# Altium schematic sheet 1_MISC.SchDoc(1_MISC)
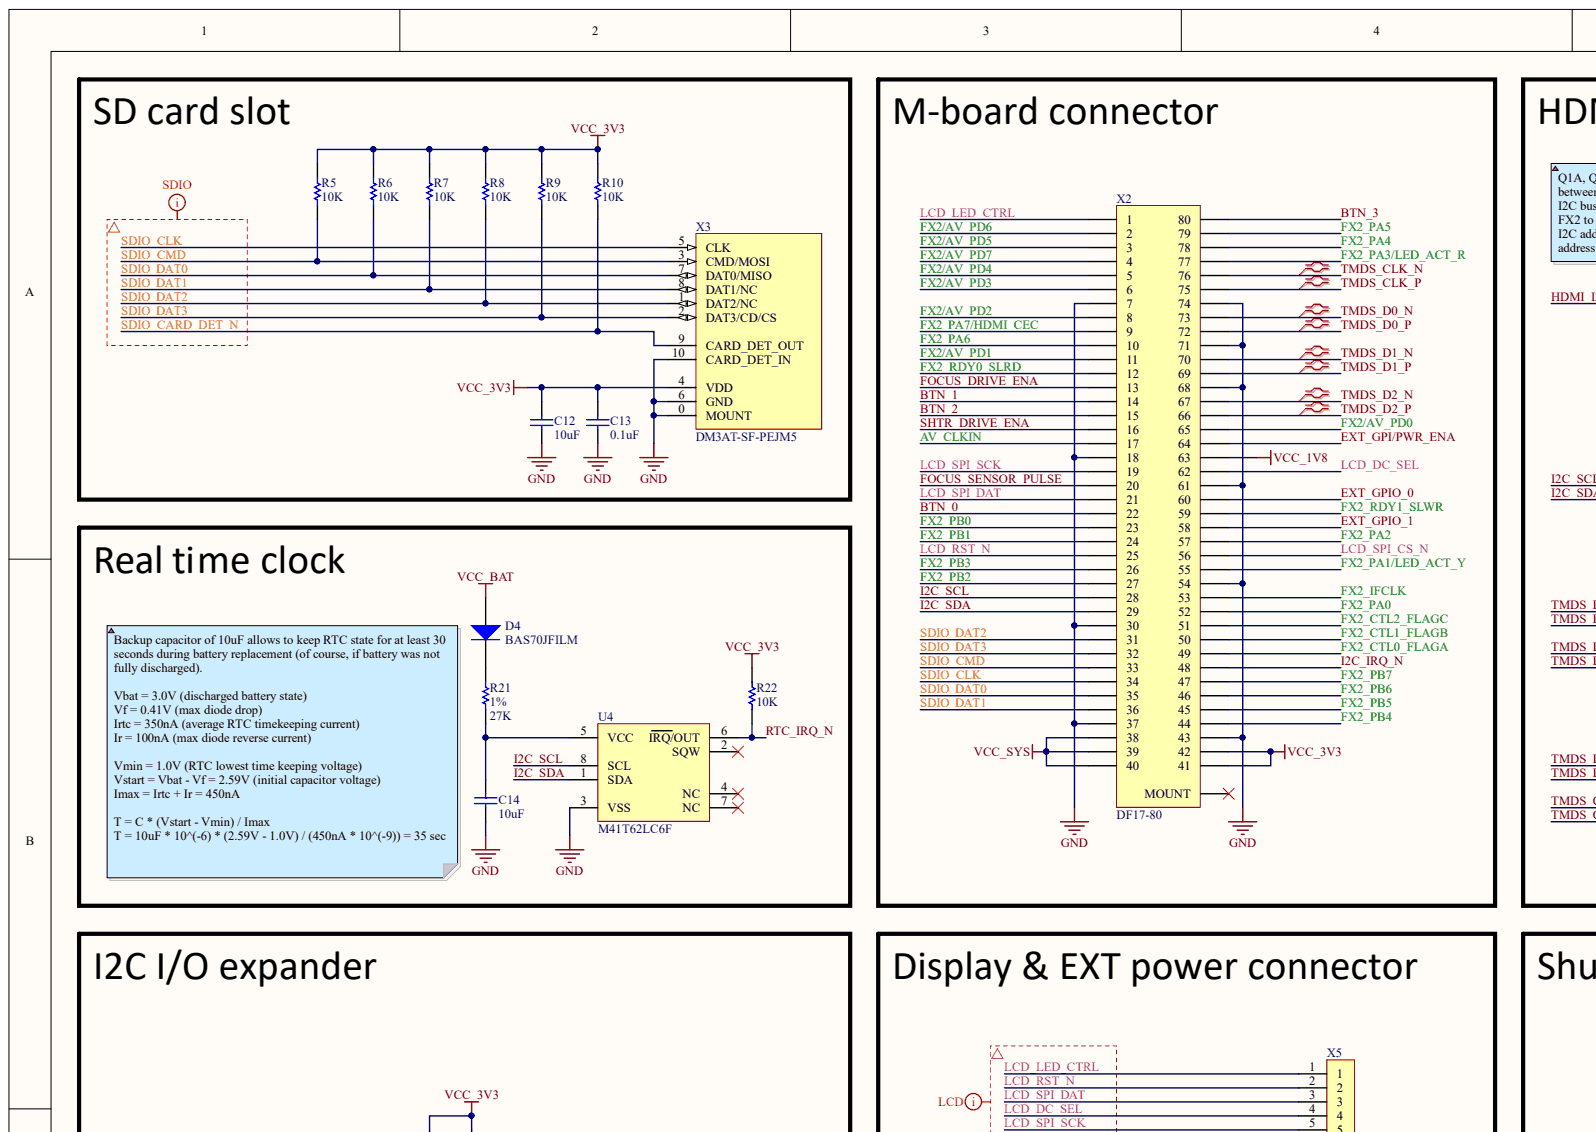
[diagram p0: 1_MISC.SchDoc, top left]
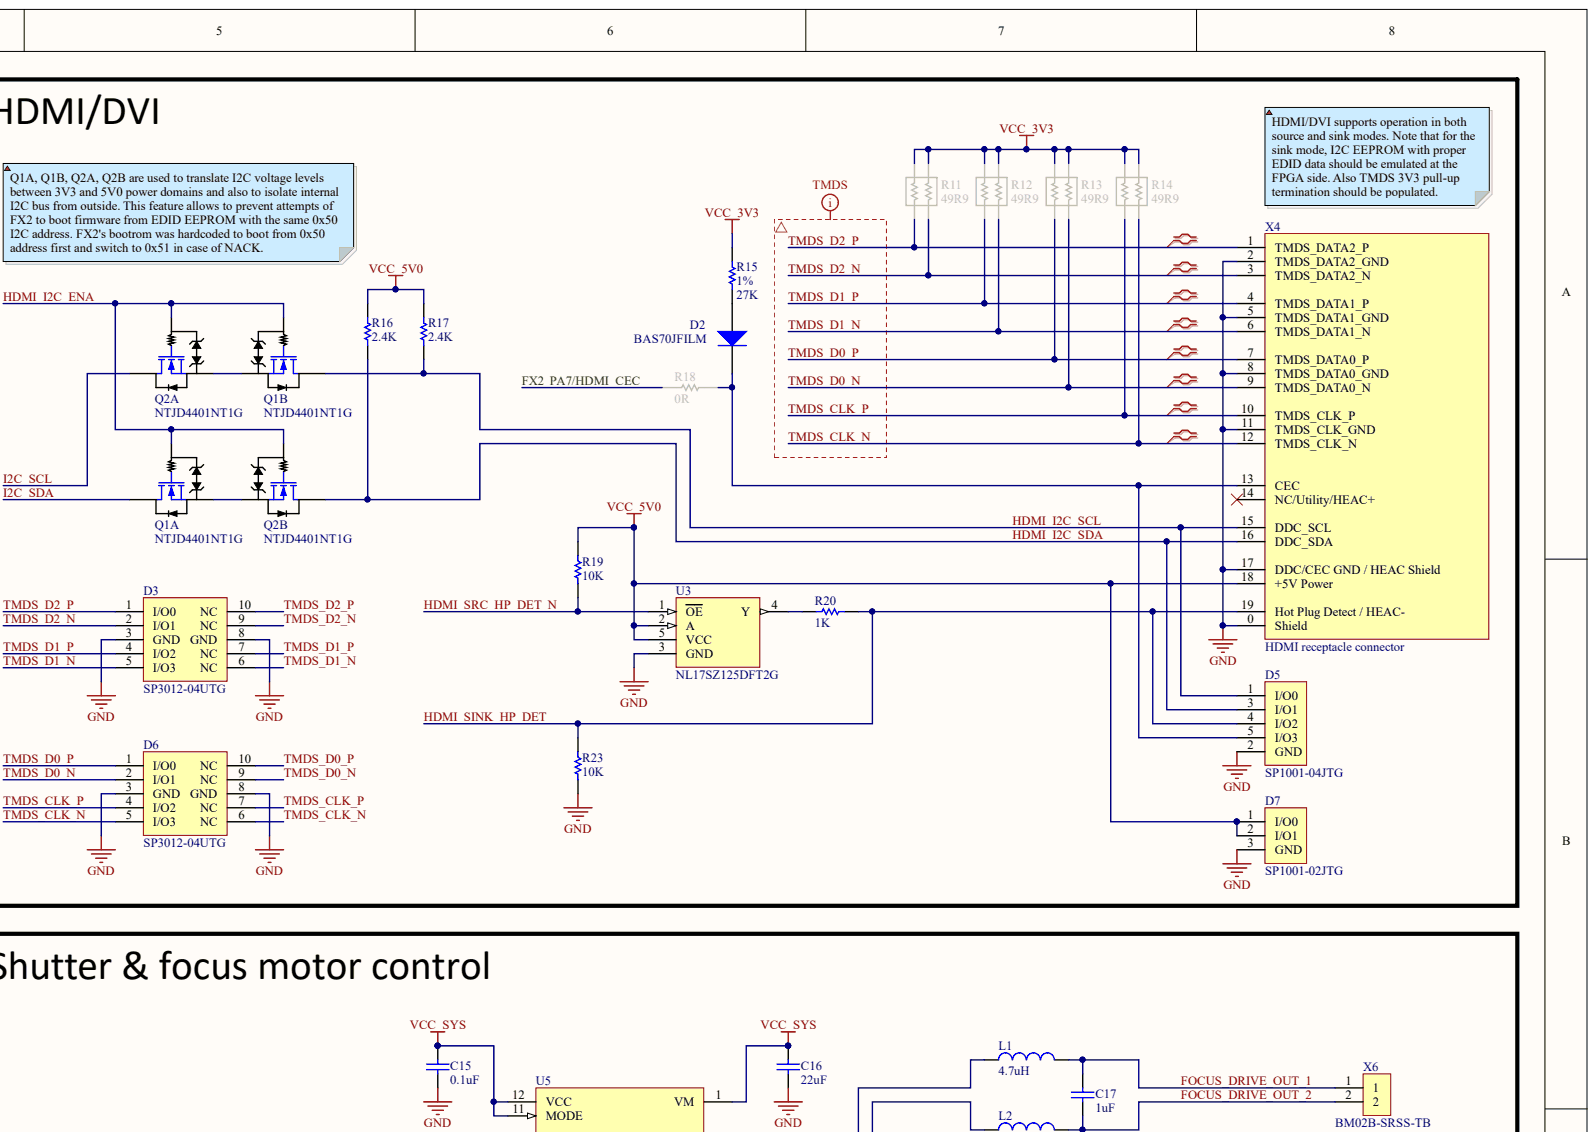
[diagram p1: 1_MISC.SchDoc, top right]
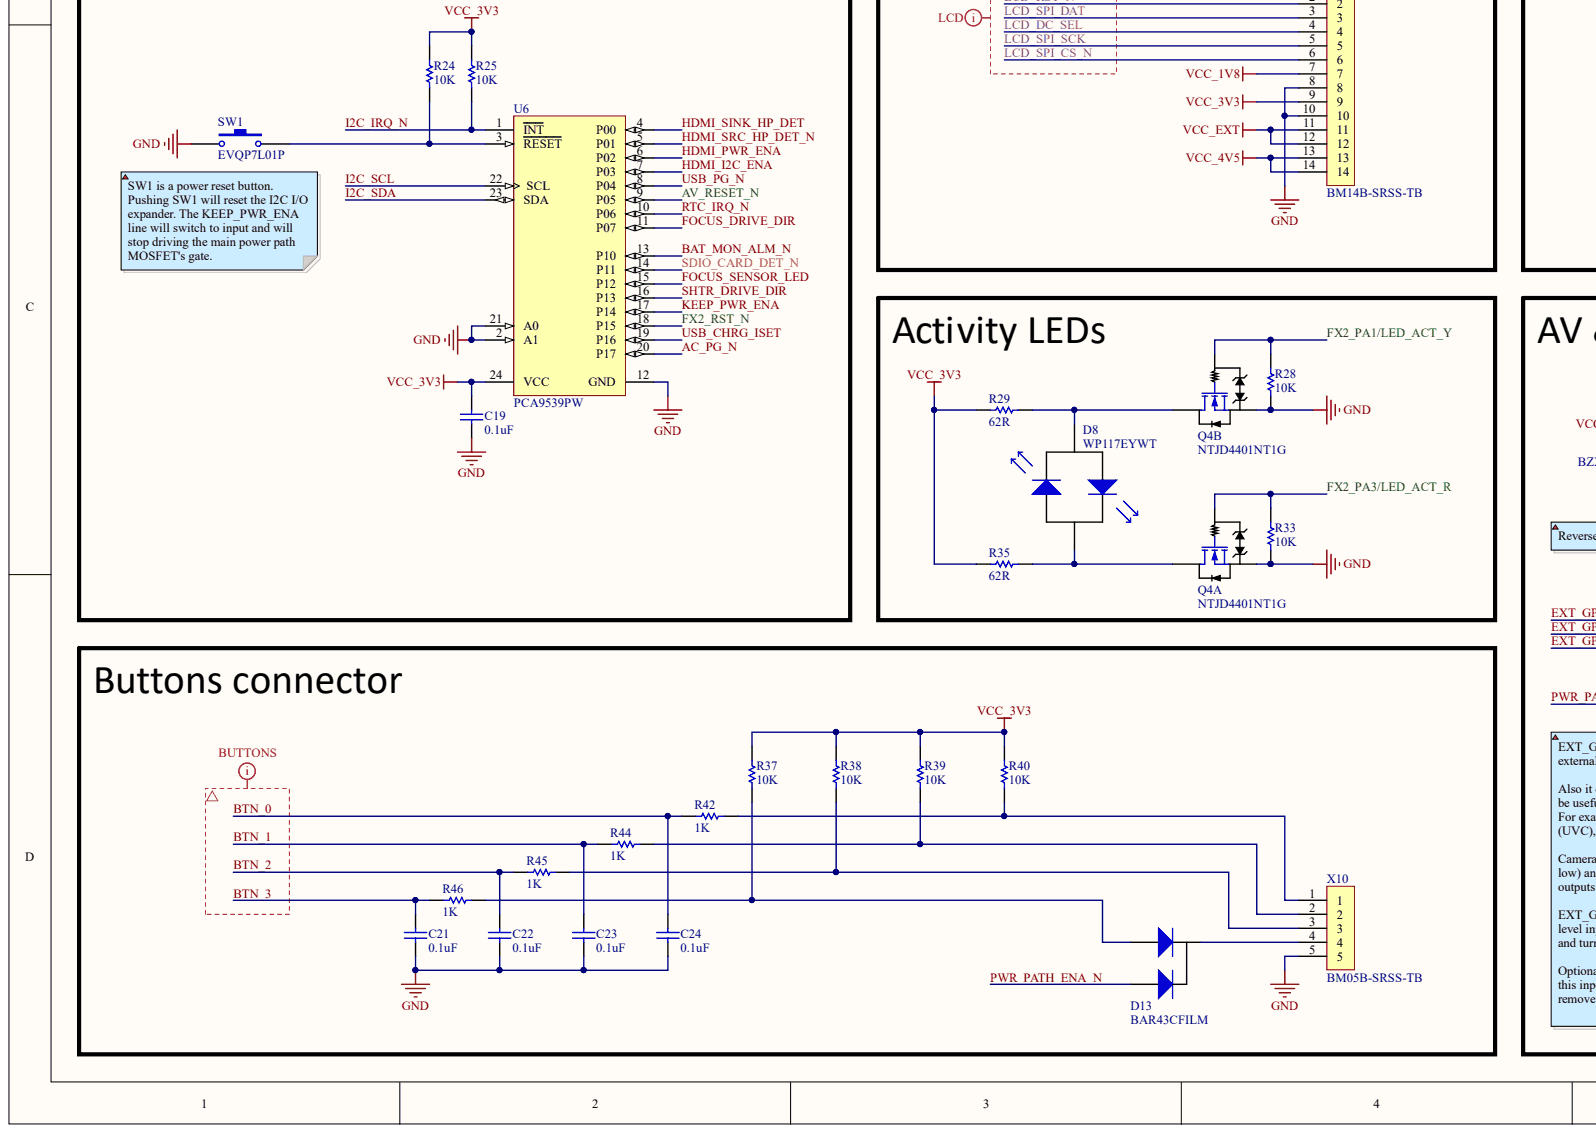
[diagram p2: 1_MISC.SchDoc, bottom left]
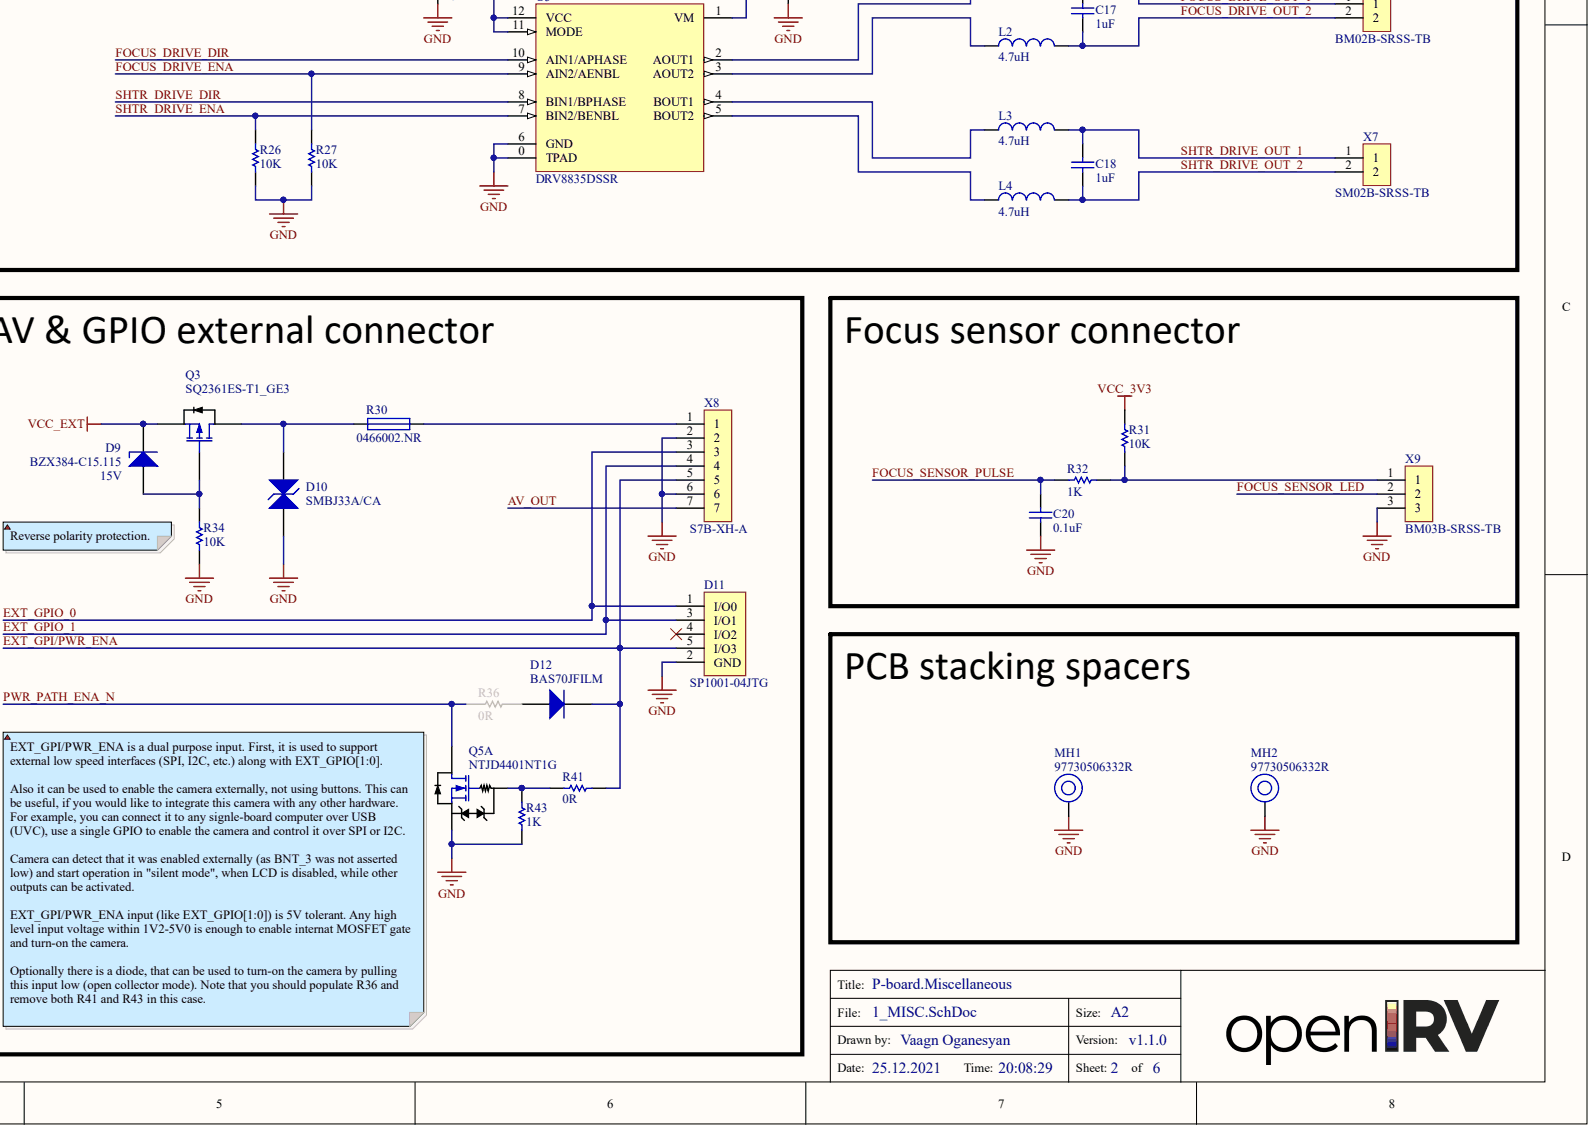
[diagram p3: 1_MISC.SchDoc, bottom right]

## Parts
C12  10uF  [C0805[2012]]
C13  0.1uF  [C0402[1005]]
C14  10uF  [C0805[2012]]
C15  0.1uF  [C0402[1005]]
C16  22uF  [C1206[3216]]
C17  1uF  [C0603[1608]]
C18  1uF  [C0603[1608]]
C19  0.1uF  [C0402[1005]]
C20  0.1uF  [C0402[1005]]
C21  0.1uF  [C0402[1005]]
C22  0.1uF  [C0402[1005]]
C23  0.1uF  [C0402[1005]]
C24  0.1uF  [C0402[1005]]
D2  BAS70JFILM  [BAS70JFILM]
D3  SP3012-04UTG  [SP3012-04UTG]
D4  BAS70JFILM  [BAS70JFILM]
D5  SP1001-04JTG  [SP1001-04JTG]
D6  SP3012-04UTG  [SP3012-04UTG]
D7  SP1001-02JTG  [SP1001-02JTG]
D8  WP117EYWT  [LED_AXIAL[7x5x2]]
D9  BZX384-C15.115  stab_voltage: 15V  [BZX384]
D10  SMBJ33A/CA  [SMBJ33A/CA]
D11  SP1001-04JTG  [SP1001-04JTG]
D12  BAS70JFILM  [BAS70JFILM]
D13  BAR43CFILM  [BAR43CFILM]
L1  value 4.7uH  [L0805[2012]]  (hidden comment: LQM21PN4R7MGRD)
L2  value 4.7uH  [L0805[2012]]  (hidden comment: LQM21PN4R7MGRD)
L3  value 4.7uH  [L0805[2012]]  (hidden comment: LQM21PN4R7MGRD)
L4  value 4.7uH  [L0805[2012]]  (hidden comment: LQM21PN4R7MGRD)
MH1  97730506332R  [97730506332R]
MH2  97730506332R  [97730506332R]
Q1  NTJD4401NT1G  [NTJD4401NT1G]  (parts A,B)
Q2  NTJD4401NT1G  [NTJD4401NT1G]  (parts A,B)
Q3  SQ2361ES-T1_GE3  [SQ2361ES-T1_GE3]
Q4  NTJD4401NT1G  [NTJD4401NT1G]  (parts A,B)
Q5  NTJD4401NT1G  [NTJD4401NT1G]  (part A)
R5  10K  [R0402[1005]]
R6  10K  [R0402[1005]]
R7  10K  [R0402[1005]]
R8  10K  [R0402[1005]]
R9  10K  [R0402[1005]]
R10  10K  [R0402[1005]]
R11  49R9  [R0404]
R12  49R9  [R0404]
R13  49R9  [R0404]
R14  49R9  [R0404]
R15  27K  Tolerance: 1%  [R0603[1608]]
R16  2.4K  [R0402[1005]]
R17  2.4K  [R0402[1005]]
R18  0R  [R0402[1005]]
R19  10K  [R0402[1005]]
R20  1K  [R0402[1005]]
R21  27K  Tolerance: 1%  [R0603[1608]]
R22  10K  [R0402[1005]]
R23  10K  [R0402[1005]]
R24  10K  [R0402[1005]]
R25  10K  [R0402[1005]]
R26  10K  [R0402[1005]]
R27  10K  [R0402[1005]]
R28  10K  [R0402[1005]]
R29  62R  [R0603[1608]]
R30  0466002.NR  [R1206[3216]]
R31  10K  [R0402[1005]]
R32  1K  [R0402[1005]]
R33  10K  [R0402[1005]]
R34  10K  [R0402[1005]]
R35  62R  [R0603[1608]]
R36  0R  [R0402[1005]]
R37  10K  [R0402[1005]]
R38  10K  [R0402[1005]]
R39  10K  [R0402[1005]]
R40  10K  [R0402[1005]]
R41  0R  [R0402[1005]]
R42  1K  [R0402[1005]]
R43  1K  [R0402[1005]]
R44  1K  [R0402[1005]]
R45  1K  [R0402[1005]]
R46  1K  [R0402[1005]]
SW1  EVQP7L01P  [EVQP7L01P]
U3  NL17SZ125DFT2G  [NL17SZ125DFT2G]
U4  M41T62LC6F  [M41T62LC6F]
U5  DRV8835DSSR  [DRV8835DSSR]
U6  PCA9539PW  [PCA9539PW]
X2  DF17-80  [DF17(3.0)-80DS-0.5V(57)]
X3  DM3AT-SF-PEJM5  [DM3AT-SF-PEJM5]
X4  HDMI receptacle connector  [471510001_EDGE_HOLE]
X5  BM14B-SRSS-TB  [BM14B-SRSS-TB]
X6  BM02B-SRSS-TB  [BM02B-SRSS-TB]
X7  SM02B-SRSS-TB  [SM02B-SRSS-TB]
X8  S7B-XH-A  [S7B-XH-A]
X9  BM03B-SRSS-TB  [BM03B-SRSS-TB]
X10  BM05B-SRSS-TB  [BM05B-SRSS-TB]

## Nets
GND: C12.1 C13.2 C14.1 C15.2 C16.1 C19.2 C20.2 C21.2 C22.2 C23.2 C24.2 D3.3(GND) D3.8(GND) D5.2(GND) D6.3(GND) D6.8(GND) D7.3 D10.1 D11.2(GND) MH1.0 MH2.0 Q4.1 Q4.4 Q5.1 R23.2 R26.1 R27.1 R28.1 R33.1 R34.2 R43.2 SW1.2 U3.3(GND) U4.3(VSS) U5.0(TPAD) U5.6(GND) U6.2(A1) U6.12(GND) U6.21(A0) X2.7 X2.18 X2.30 X2.37 X2.43 X2.54 X2.61 X2.68 X2.71 X2.74 X3.0(MOUNT) X3.6(GND) X3.10(CARD_DET_IN) X4.0(Shield) X4.2(TMDS_DATA2_GND) X4.5(TMDS_DATA1_GND) X4.8(TMDS_DATA0_GND) X4.11(TMDS_CLK_GND) X4.17(DDC/CEC_GND_/_HEAC_Shield) X5.8 X5.10 X8.2 X8.6 X9.3 X10.5
VCC_1V8: X2.63 X5.7
VCC_3V3: C12.2 C13.1 C19.1 R5.2 R6.2 R7.2 R8.2 R9.2 R10.2 R11.3 R11.4 R12.3 R12.4 R13.3 R13.4 R14.3 R14.4 R15.1 R22.1 R24.1 R25.1 R29.1 R31.2 R35.1 R37.1 R38.2 R39.2 R40.2 U6.24(VCC) X2.41 X2.42 X3.4(VDD) X5.9
VCC_4V5: X5.13 X5.14
VCC_5V0: D7.1 D7.2 R16.2 R17.2 R19.2 U3.2(A) U3.5(VCC) X4.18(+5V_Power)
VCC_BAT: D4.1
VCC_EXT: D9.2 Q3.2 X5.11 X5.12
VCC_SYS: C15.1 C16.2 U5.1(VM) U5.11(MODE) U5.12(VCC) X2.38 X2.39 X2.40
AC_PG_N: U6.20(P17)
AV_CLKIN: X2.17
AV_OUT: X8.7
AV_RESET_N: U6.9(P05)
BAT_MON_ALM_N: U6.13(P10)
BTN_0: C24.1 R42.2 X2.22
BTN_1: C23.1 R44.2 X2.14
BTN_2: C22.1 R45.2 X2.15
BTN_3: C21.1 R46.2 X2.80
EXT_GPI/PWR_ENA: D11.5(I/O3) D12.2 R41.1 X2.64 X8.5
EXT_GPIO_0: D11.1(I/O0) X2.60 X8.3
EXT_GPIO_1: D11.3(I/O1) X2.58 X8.4
FOCUS_DRIVE_DIR: U5.10(AIN1/APHASE) U6.11(P07)
FOCUS_DRIVE_ENA: R27.2 U5.9(AIN2/AENBL) X2.13
FOCUS_DRIVE_OUT_1: C17.1 L1.2 X6.1
FOCUS_DRIVE_OUT_2: C17.2 L2.2 X6.2
FOCUS_SENSOR_LED: U6.15(P12) X9.2
FOCUS_SENSOR_PULSE: C20.1 R32.2 X2.20
FX2/AV_PD0: X2.65
FX2/AV_PD1: X2.11
FX2/AV_PD2: X2.8
FX2/AV_PD3: X2.6
FX2/AV_PD4: X2.5
FX2/AV_PD5: X2.3
FX2/AV_PD6: X2.2
FX2/AV_PD7: X2.4
FX2_CTL0_FLAGA: X2.49
FX2_CTL1_FLAGB: X2.50
FX2_CTL2_FLAGC: X2.51
FX2_IFCLK: X2.53
FX2_PA0: X2.52
FX2_PA1/LED_ACT_Y: Q4.5 R28.2 X2.55
FX2_PA2: X2.57
FX2_PA3/LED_ACT_R: Q4.2 R33.2 X2.77
FX2_PA4: X2.78
FX2_PA5: X2.79
FX2_PA6: X2.10
FX2_PA7/HDMI_CEC: R18.2 X2.9
FX2_PB0: X2.23
FX2_PB1: X2.24
FX2_PB2: X2.27
FX2_PB3: X2.26
FX2_PB4: X2.44
FX2_PB5: X2.45
FX2_PB6: X2.46
FX2_PB7: X2.47
FX2_RDY0_SLRD: X2.12
FX2_RDY1_SLWR: X2.59
FX2_RST_N: U6.18(P15)
HDMI_I2C_ENA: Q1.2 Q1.5 Q2.2 Q2.5 U6.7(P03)
HDMI_I2C_SCL: D5.1(I/O0) Q1.3 R17.1 X4.15(DDC_SCL)
HDMI_I2C_SDA: D5.3(I/O1) Q2.3 R16.1 X4.16(DDC_SDA)
HDMI_PWR_ENA: U6.6(P02)
HDMI_SINK_HP_DET: D5.4(I/O2) R20.1 R23.1 U6.4(P00) X4.19(Hot_Plug_Detect_/_HEAC-)
HDMI_SRC_HP_DET_N: R19.1 U3.1(OE) U6.5(P01)
I2C_IRQ_N: R25.2 U6.1(INT) X2.48
I2C_SCL: Q2.6 U4.8(SCL) U6.22(SCL) X2.28
I2C_SDA: Q1.6 U4.1(SDA) U6.23(SDA) X2.29
KEEP_PWR_ENA: U6.17(P14)
LCD_DC_SEL: X2.62 X5.4
LCD_LED_CTRL: X2.1 X5.1
LCD_RST_N: X2.25 X5.2
LCD_SPI_CS_N: X2.56 X5.6
LCD_SPI_DAT: X2.21 X5.3
LCD_SPI_SCK: X2.19 X5.5
PWR_PATH_ENA_N: D13.2 Q5.6 R36.1
RTC_IRQ_N: R22.2 U4.6(IRQ/OUT) U6.10(P06)
SDIO_CARD_DET_N: R10.1 U6.14(P11) X3.9(CARD_DET_OUT)
SDIO_CLK: X2.34 X3.5(CLK)
SDIO_CMD: R5.1 X2.33 X3.3(CMD/MOSI)
SDIO_DAT0: R6.1 X2.35 X3.7(DAT0/MISO)
SDIO_DAT1: R7.1 X2.36 X3.8(DAT1/NC)
SDIO_DAT2: R8.1 X2.31 X3.1(DAT2/NC)
SDIO_DAT3: R9.1 X2.32 X3.2(DAT3/CD/CS)
SHTR_DRIVE_DIR: U5.8(BIN1/BPHASE) U6.16(P13)
SHTR_DRIVE_ENA: R26.2 U5.7(BIN2/BENBL) X2.16
SHTR_DRIVE_OUT_1: C18.1 L3.2 X7.1
SHTR_DRIVE_OUT_2: C18.2 L4.2 X7.2
TMDS_CLK_N: D6.5(I/O3) D6.6(NC) R14.1 X2.76 X4.12(TMDS_CLK_N)
TMDS_CLK_P: D6.4(I/O2) D6.7(NC) R14.2 X2.75 X4.10(TMDS_CLK_P)
TMDS_D0_N: D6.2(I/O1) D6.9(NC) R13.1 X2.73 X4.9(TMDS_DATA0_N)
TMDS_D0_P: D6.1(I/O0) D6.10(NC) R13.2 X2.72 X4.7(TMDS_DATA0_P)
TMDS_D1_N: D3.5(I/O3) D3.6(NC) R12.1 X2.70 X4.6(TMDS_DATA1_N)
TMDS_D1_P: D3.4(I/O2) D3.7(NC) R12.2 X2.69 X4.4(TMDS_DATA1_P)
TMDS_D2_N: D3.2(I/O1) D3.9(NC) R11.1 X2.67 X4.3(TMDS_DATA2_N)
TMDS_D2_P: D3.1(I/O0) D3.10(NC) R11.2 X2.66 X4.1(TMDS_DATA2_P)
USB_CHRG_ISET: U6.19(P16)
USB_PG_N: U6.8(P04)
NetC14_2: C14.2 R21.2 U4.5(VCC)
NetD2_1: D2.1 R15.2
NetD2_2: D2.2 D5.5(I/O3) R18.1 X4.13(CEC)
NetD4_2: D4.2 R21.1
NetD8_1: D8.1 Q4.3 R29.2
NetD8_2: D8.2 Q4.6 R35.2
NetD9_1: D9.1 Q3.1 R34.1
NetD10_2: D10.2 Q3.3 R30.1
NetD12_1: D12.1 R36.2
NetD13_1: D13.1 R37.2 R46.1
NetD13_3: D13.3 X10.4
NetL1_1: L1.1 U5.2(AOUT1)
NetL2_1: L2.1 U5.3(AOUT2)
NetL3_1: L3.1 U5.4(BOUT1)
NetL4_1: L4.1 U5.5(BOUT2)
NetQ1_1: Q1.1 Q2.4
NetQ1_4: Q1.4 Q2.1
NetQ5_2: Q5.2 R41.2 R43.1
NetR20_2: R20.2 U3.4(Y)
NetR24_2: R24.2 SW1.1 U6.3(RESET)
NetR30_2: R30.2 X8.1
NetR31_1: R31.1 R32.1 X9.1
NetR38_1: R38.1 R45.1 X10.3
NetR39_1: R39.1 R44.1 X10.2
NetR40_1: R40.1 R42.1 X10.1
N00098:
N00099:
N00100:
N00101:

Not connected: D11 4(I/O2); U4 2(SQW) 4(NC) 7(NC); X2 0(MOUNT); X4 14(NC/Utility/HEAC+)

## Library data of the parts
C12 C14 C16 = CAP: Dielectric: X7R; Tolerance: 10%; Voltage: 10V; Component Kind: Standard; Library Name: general.IntLib; Mounting: SMD
  C12 C14: Value: 10uF
  C16: Value: 22uF
C13 C15 C19-C24 = CAP: Dielectric: X7R; Tolerance: 10%; Voltage: 50V; Component Kind: Standard; Library Name: general.IntLib; Mounting: SMD; Value: 0.1uF
C17 C18 = CAP: Dielectric: X7R; Tolerance: 10%; Voltage: 25V; Component Kind: Standard; Library Name: general.IntLib; Mounting: SMD; Value: 1uF
D2 D4 D12 = Diode_1: Diode; Component Kind: Standard; Library Name: general.IntLib; ManufacturerPartNumber: BAS70JFILM
D3 D6 = SP3012-04UTG: ESD protection diode; Component Kind: Standard; Library Name: general.IntLib
D5 D11 = SP1001-04JTG: ESD protection diode; Component Kind: Standard; Library Name: general.IntLib
D7 = SP1001-02JTG: ESD protection diode; Component Kind: Standard; Library Name: general.IntLib
D8 = LED_DUAL: Component Kind: Standard; Library Name: general.IntLib; ManufacturerPartNumber: WP117EYWT
D9 = Diode_3: Zener diode; Component Kind: Standard; Library Name: general.IntLib; ManufacturerPartNumber: BZX384-C15.115
D10 = Diode_5: TVS diode; Component Kind: Standard; Library Name: general.IntLib; ManufacturerPartNumber: SMBJ33A/CA
D13 = Diode_2: Component Kind: Standard; Library Name: general.IntLib
L1-L4 = Inductor: Component Kind: Standard; Library Name: general.IntLib; ManufacturerPartNumber: LQM21PN4R7MGRD
MH1 MH2 = 97730506332R: Spacer M1.6; Component Kind: Standard; Library Name: general.IntLib
Q1 Q2 Q4 Q5 = NTJD4401NT1G: Dual N-channel mosfet; Component Kind: Standard; Library Name: general.IntLib
R5-R10 R16-R20 R22-R28 R31-R34 R36-R46 = RES: Power: 0.06W; Tolerance: 5%; Component Kind: Standard; Library Name: general.IntLib; Mounting: SMD
  R5-R10 R19 R22-R28 R31 R33 R34 R37-R40: Value: 10K
  R16 R17: Value: 2.4K
  R18 R36 R41: Value: 0R
  R20 R32 R42-R46: Value: 1K
R11-R14 = RES_ARRAY_2: Power: 0.06W; Tolerance: 1%; Component Kind: Standard; Library Name: general.IntLib; Mounting: SMD; Value: 49R9
R15 R21 = RES: Power: 0.1W; Component Kind: Standard; Library Name: general.IntLib; Mounting: SMD; Value: 27K
R29 R35 = RES: Power: 0.1W; Tolerance: 5%; Component Kind: Standard; Library Name: general.IntLib; Mounting: SMD; Value: 62R
R30 = Fuse: Current: 2A; Component Kind: Standard; Library Name: general.IntLib; ManufacturerPartNumber: 0466002.NR; Mounting: SMD
SW1 = Button: Tactile Switch; Component Kind: Standard; Library Name: general.IntLib; ManufacturerPartNumber: EVQP7L01P
U3 = NL17SZ125DFT2G: Non-Inverting 3-State Buffer; Component Kind: Standard; Library Name: general.IntLib
U4 = M41T62LC6F: Real Time Clock; Component Kind: Standard; Library Name: general.IntLib
U5 = DRV8835DSSR: Dual Low Voltage H-Bridge IC; Component Kind: Standard; Library Name: general.IntLib
X4 = 471510001: Component Kind: Standard; Library Name: general.IntLib
X8 = S7B-XH-A: JST connector 2.5mm 7-pin; Component Kind: Standard; Library Name: general.IntLib
Q3 = SQ2361ES-T1_GE3: Component Kind: Standard; Library Name: general.IntLib
U6 = PCA9539PW: Component Kind: Standard; Description: PCA9539PW; Library Name: general.IntLib
X2 = DF17-80: Component Kind: Standard; Library Name: general.IntLib
X3 = DM3AT-SF-PEJM5: Component Kind: Standard; Library Name: general.IntLib
X5 = BM14B-SRSS-TB: Component Kind: Standard; Library Name: general.IntLib
X6 = BM02B-SRSS-TB: Component Kind: Standard; Library Name: general.IntLib
X7 = SM02B-SRSS-TB: Component Kind: Standard; Library Name: general.IntLib
X9 = BM03B-SRSS-TB: Component Kind: Standard; Library Name: general.IntLib
X10 = BM05B-SRSS-TB: Component Kind: Standard; Library Name: general.IntLib
